# Altium schematic sheet power.SchDoc
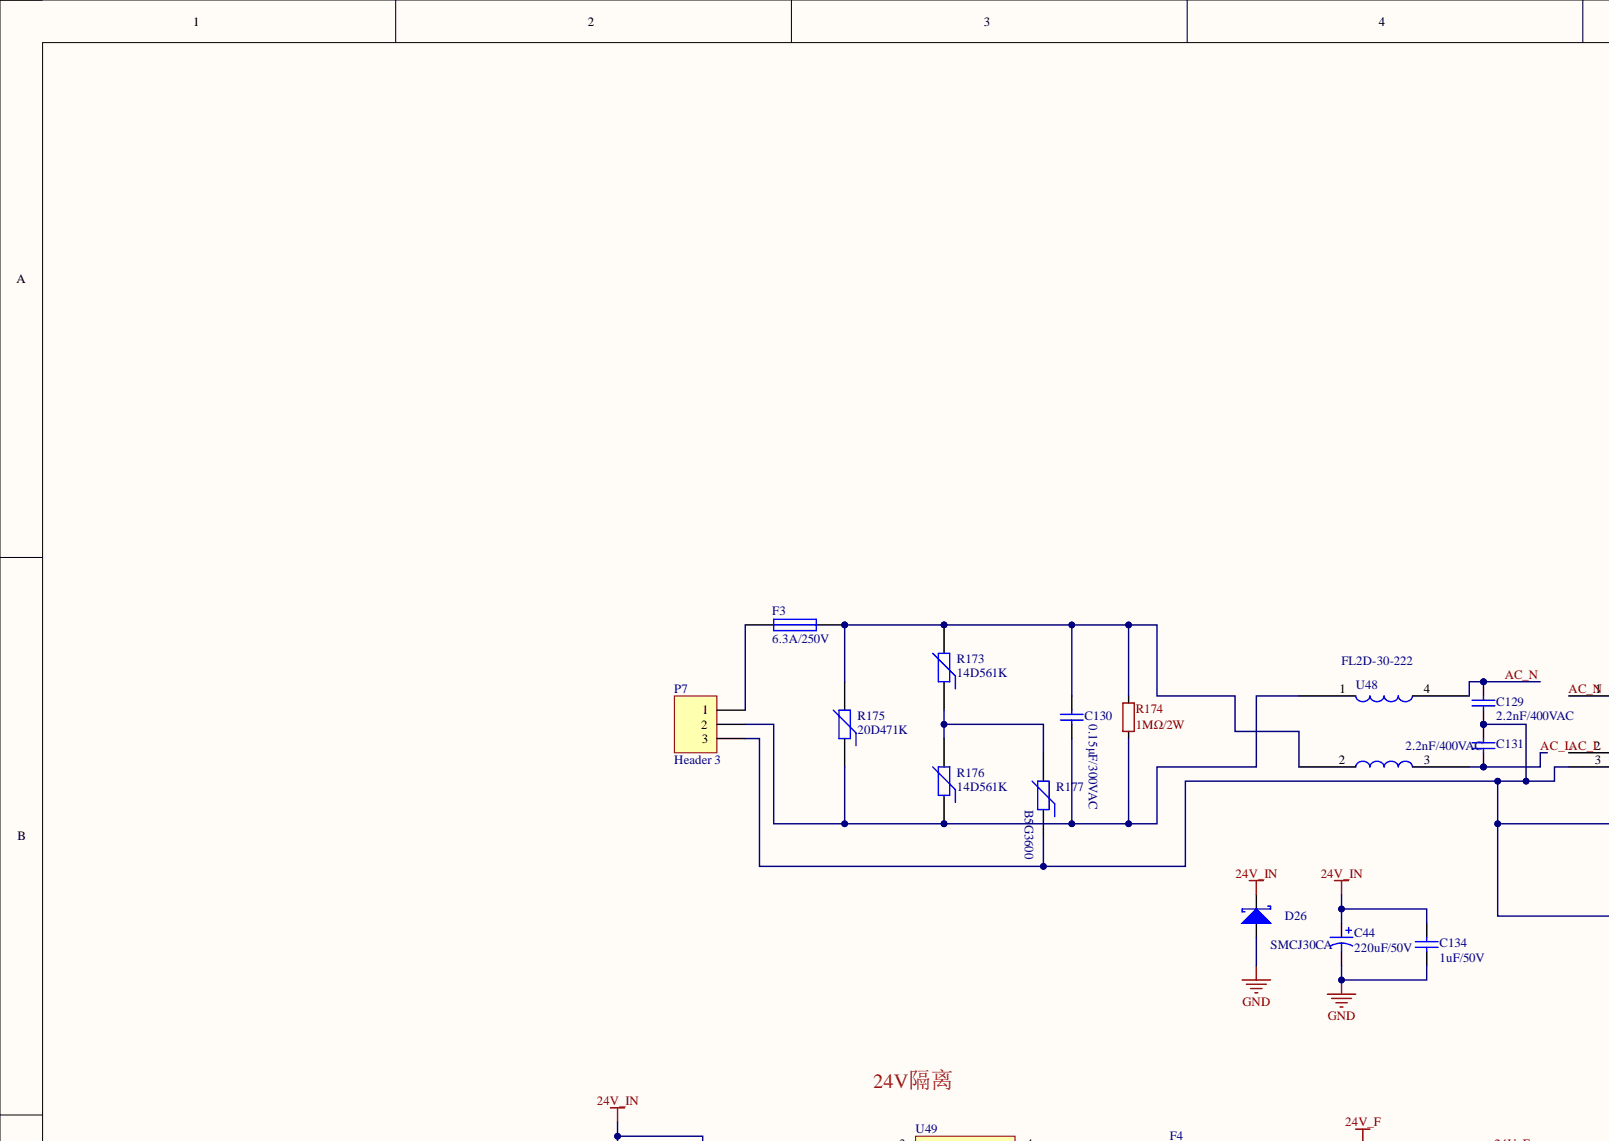
[diagram p0: power.SchDoc, top left]
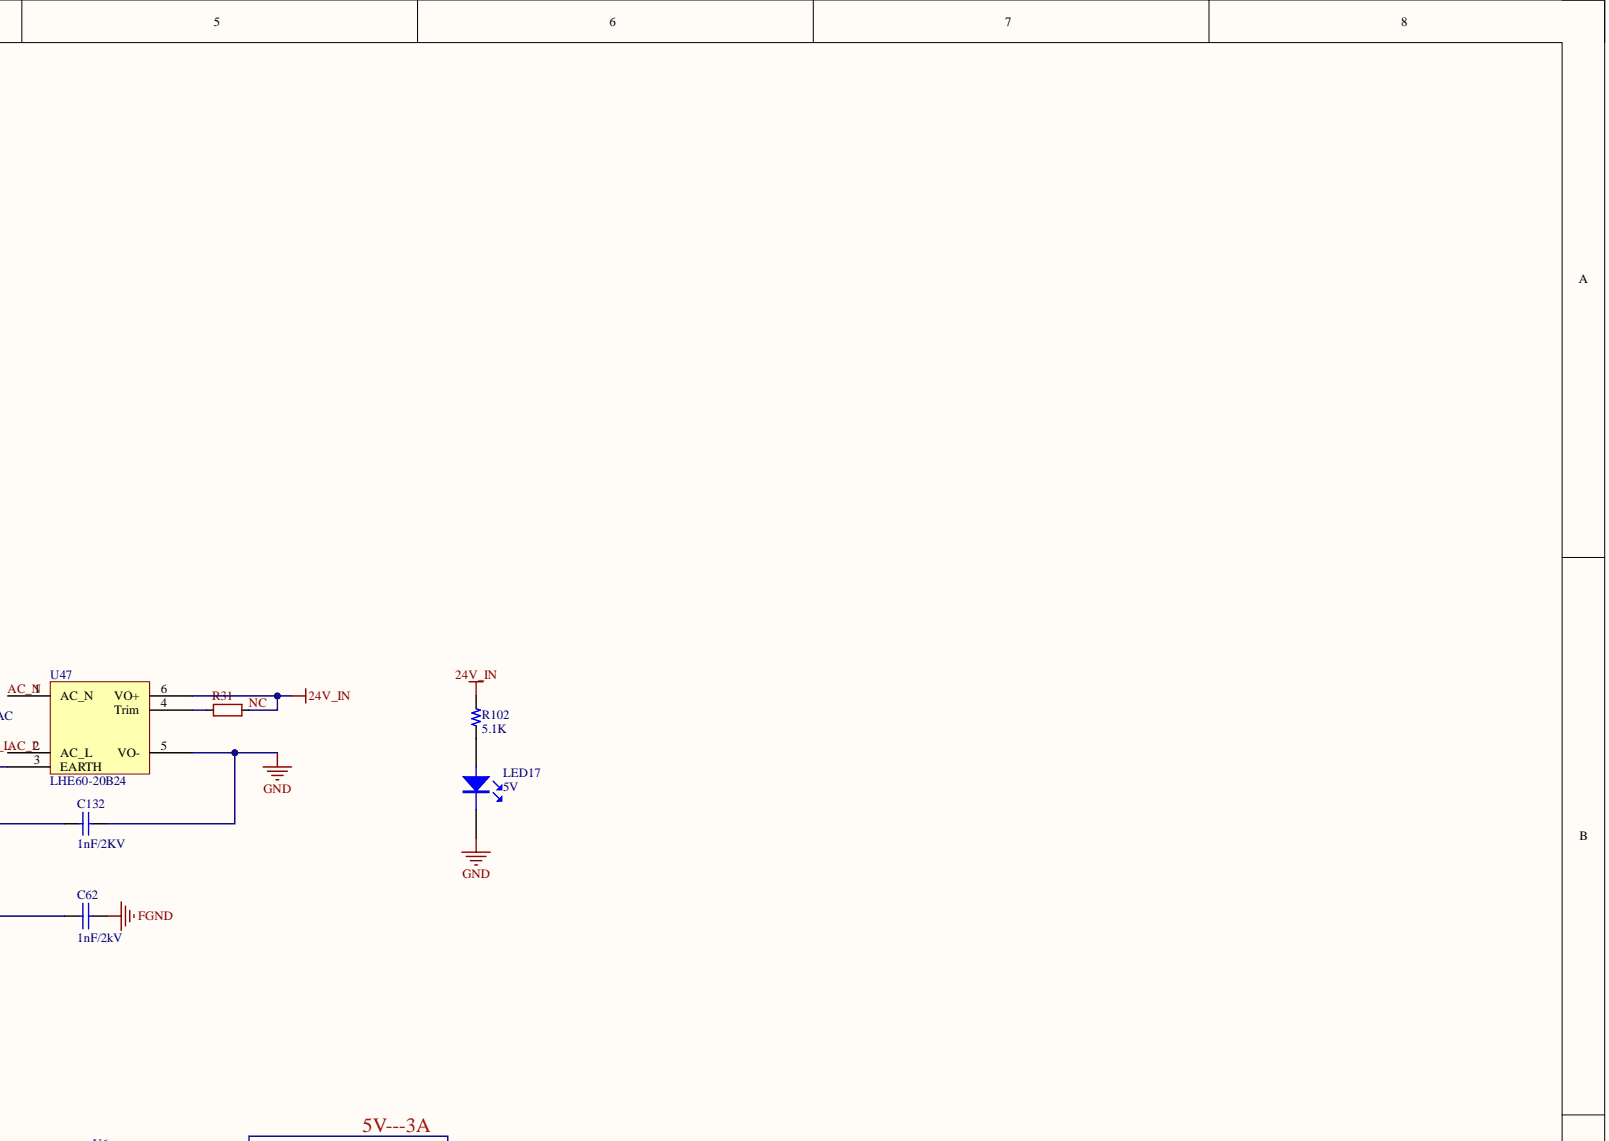
[diagram p1: power.SchDoc, top right]
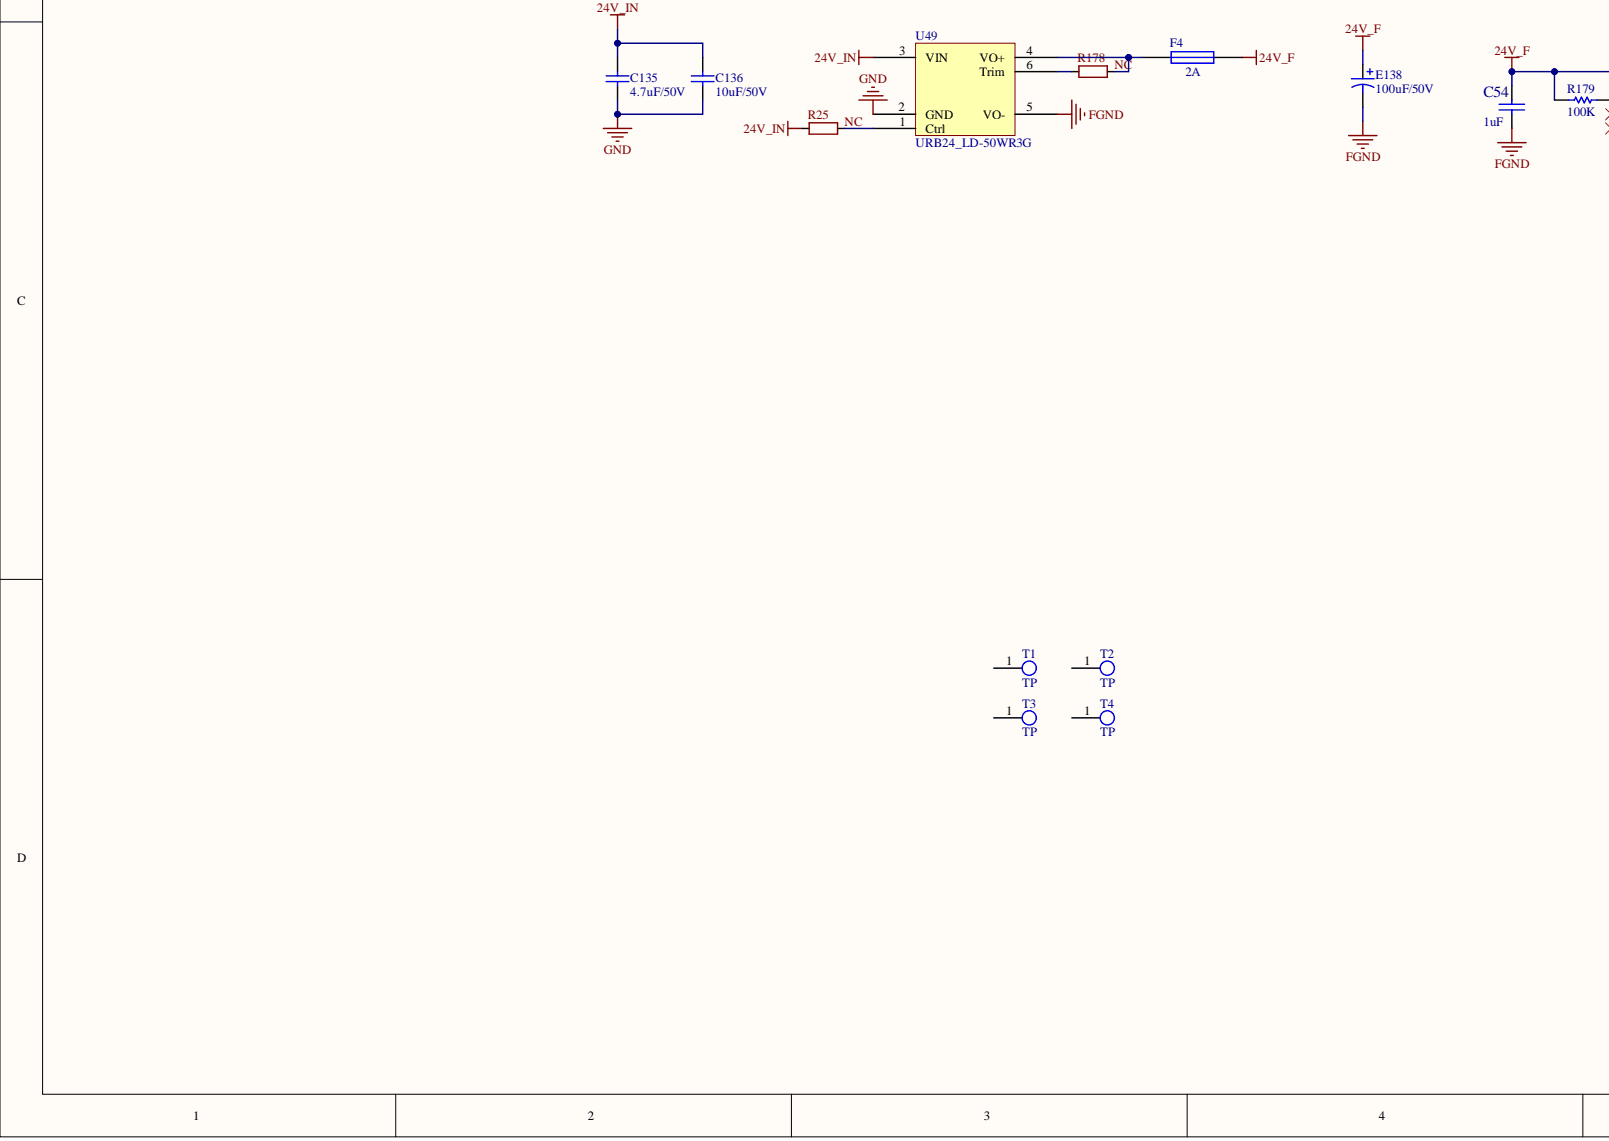
[diagram p2: power.SchDoc, bottom left]
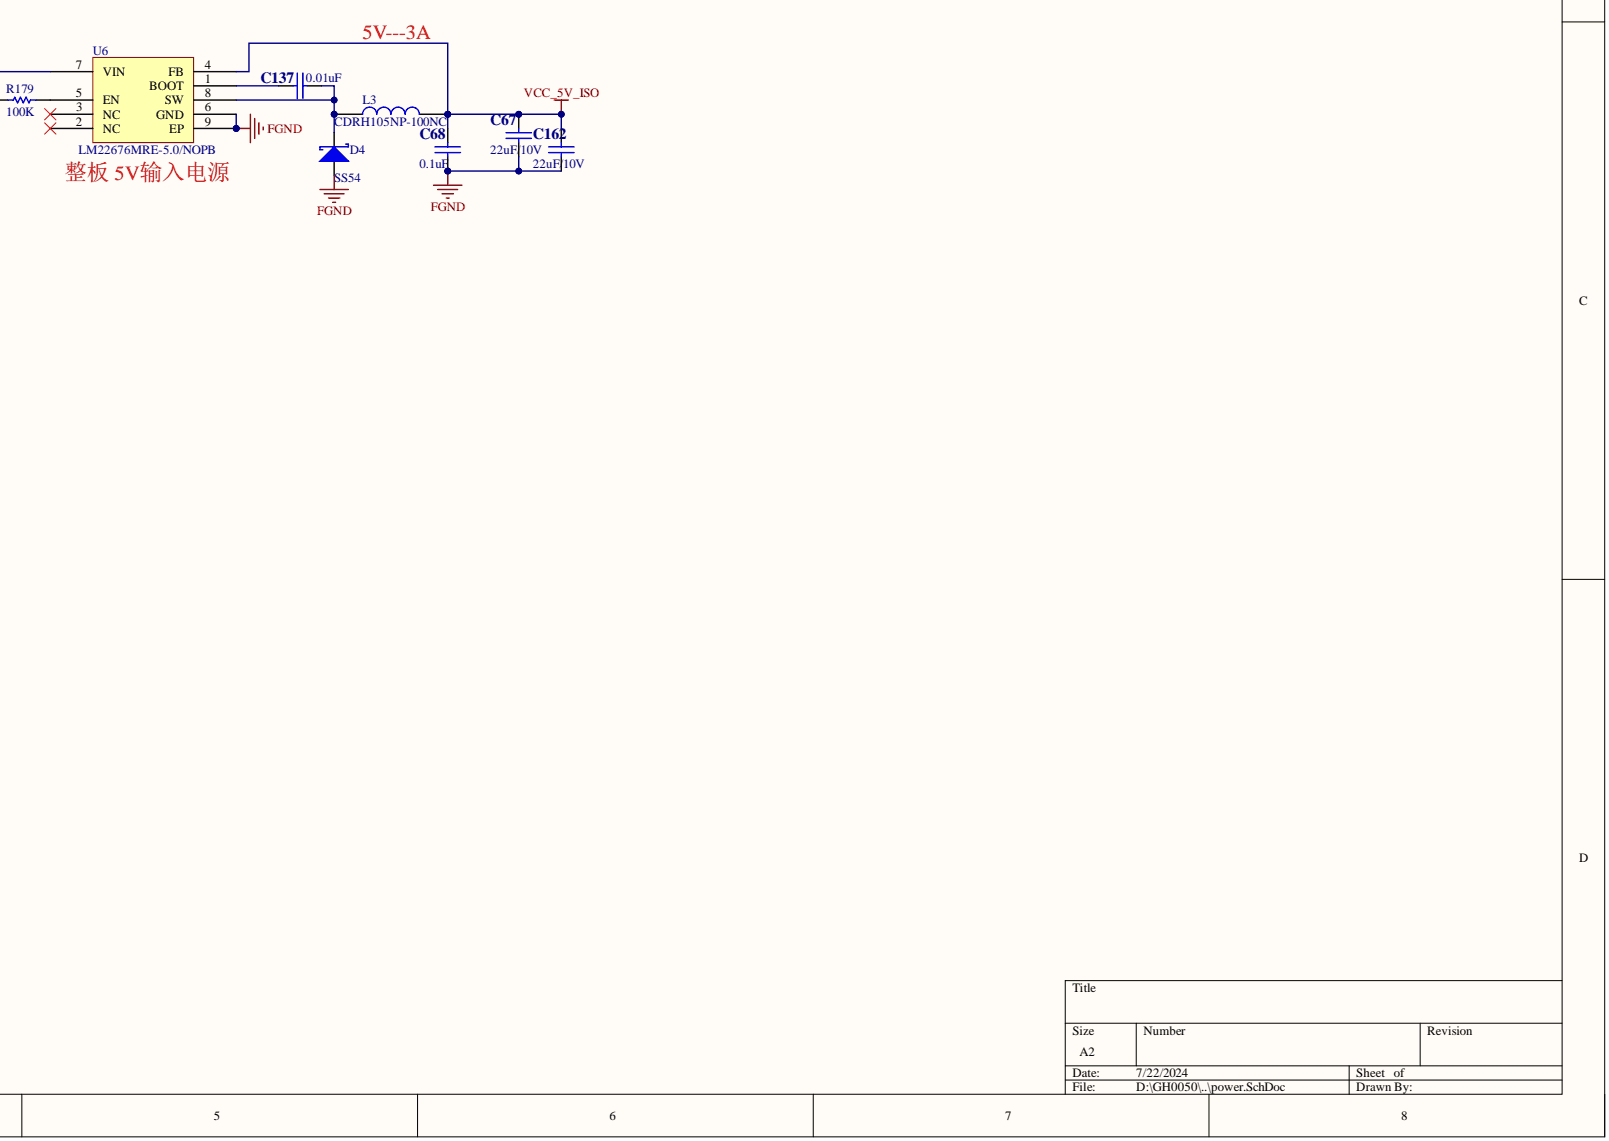
[diagram p3: power.SchDoc, bottom right]

## Parts
C44  220uF/50V  [Φ10×10]
C54  1uF  [0603C]
C62  1nF/2kV  [1206C]
C67  22uF/10V  [1210C]
C68  0.1uF  [0603C]
C129  2.2nF/400VAC  [15x18]
C130  0.15μF/300VAC  [15x18]
C131  2.2nF/400VAC  [15x18]
C132  1nF/2KV  [1206C]
C134  1uF/50V  [1210C]
C135  4.7uF/50V  [1210C]
C136  10uF/50V  [1210C]
C137  0.01uF  [0603C]
C162  22uF/10V  [1210C]
D4  SS54  [SMC]
D26  SMCJ30CA  [SMC]
E138  100uF/50V  [Φ10×10]
F3  6.3A/250V  [可视开关电源保险丝座]
F4  2A  [1812-F]
L3  CDRH105NP-100NC  [CDRH105]
LED17  5V  [0603LED]
P7  Header 3  [KF128V-3P]
R25  NC  [0603r]
R31  NC  [0603r]
R102  5.1K  [0603R]
R173  14D561K  [14D561K]
R174  1MΩ/2W  [5x15.5]
R175  20D471K  [20D471K]
R176  14D561K  [14D561K]
R177  B5G3600  [B5G3600]
R178  NC  [0603r]
R179  100K  [0603R]
T1  TP  [m2.5]
T2  TP  [m2.5]
T3  TP  [m2.5]
T4  TP  [m2.5]
U6  LM22676MRE-5.0/NOPB  [SOP127P600X168_HS-8N]
U47  LHE60-20B24  [LHE60-20B24]
U48  FL2D-30-222  [TY-100P]
U49  URB24_LD-50WR3G  [URB24_LD-50WR3G]

## Nets
24V_F: C54.2 E138.1 F4.2 R179.2 U6.7(VIN)
24V_IN: C44.1 C134.2 C135.2 C136.2 D26.2 R25.1 R31.2 R102.2 U47.6(VO+) U49.3(VIN)
FGND: C54.1 C62.1 C67.2 C68.2 C162.2 D4.1 E138.2 U6.6(GND) U6.9(EP) U49.5(VO-)
GND: C44.2 C132.1 C134.1 C135.1 C136.1 D26.1 LED17.2 U47.5(VO-) U49.2(GND)
VCC_5V_ISO: C67.1 C68.1 C162.1 L3.2 U6.4(FB)
AC_L: C131.1 U47.2(AC_L) U48.3
AC_N: C129.2 U47.1(AC_N) U48.4
NetC62_2: C62.2 C129.1 C131.2 C132.2 P7.3 R177.1 U47.3(EARTH)
NetC130_1: C130.1 P7.2 R174.2 R175.1 R176.1 U48.1
NetC130_2: C130.2 F3.2 R173.2 R174.1 R175.2 U48.2
NetC137_1: C137.1 D4.2 L3.1 U6.8(SW)
NetC137_2: C137.2 U6.1(BOOT)
NetF3_1: F3.1 P7.1
NetF4_1: F4.1 R178.2 U49.4(VO+)
NetLED17_1: LED17.1 R102.1
NetR25_2: R25.2 U49.1(Ctrl)
NetR31_1: R31.1 U47.4(Trim)
NetR173_1: R173.1 R176.2 R177.2
NetR178_1: R178.1 U49.6(Trim)
NetR179_1: R179.1 U6.5(EN)

Not connected: T1 1; T2 1; T3 1; T4 1; U6 2(NC) 3(NC)

## Library data of the parts
C44 = Cap Pol1: Polarized Capacitor (Radial); Component Kind: Standard; LatestRevisionDate: 17-Jul-2002; LatestRevisionNote: Re-released for DXP Platform.; Library Name: Miscellaneous Devices.IntLib; PackageReference: RB7.6-15; Published: 8-Jun-2000; Signal Integrity: Cap Pol; Simulation: CAP; Value: 220uF/50V; Publisher: Altium Limited
C54 C62 C67 C68 C137 C162 = CAP: Capacitor; Component Kind: Standard
C129-C132 C134-C136 = Cap: Capacitor; Value: 22nF; Component Kind: Standard; LatestRevisionDate: 17-Jul-2002; LatestRevisionNote: Re-released for DXP Platform.; Library Name: Miscellaneous Devices.IntLib; PackageDescription: Radial Cap, Thru-Hole; 2 Leads; 0.3 in Pin Spacing; PackageReference: RAD-0.3; Published: 8-Jun-2000; Signal Integrity: Cap; Simulation: CAP; Publisher: Altium Limited
D4 D26 = DIODE S: Schottky Diode; Component Kind: Standard
E138 = Cap Pol1: Polarized Capacitor (Radial); Value: 100pF; Component Kind: Standard; LatestRevisionDate: 17-Jul-2002; LatestRevisionNote: Re-released for DXP Platform.; Library Name: Miscellaneous Devices.IntLib; PackageReference: RB7.6-15; Published: 8-Jun-2000; Signal Integrity: Cap Pol; Simulation: CAP; Publisher: Altium Limited
F3 F4 = Fuse 1: Fuse; Component Kind: Standard; LatestRevisionDate: 17-Jul-2002; LatestRevisionNote: Re-released for DXP Platform.; Library Name: Miscellaneous Devices.IntLib; PackageDescription: Thru-Hole; 2 Leads; PackageReference: PIN-W2/E2.8; Published: 8-Jun-2000; Simulation: FUSE; Publisher: Altium Limited
L3 = INDUCTOR: Component Kind: Standard
LED17 = LED: Component Kind: Standard
P7 = Header 3: Header, 3-Pin; Component Kind: Standard; LatestRevisionDate: 17-Jul-2002; LatestRevisionNote: Re-released for DXP Platform.; Library Name: Miscellaneous Connectors.IntLib; Signal Integrity: Connector; Publisher: Altium Limited
R25 R31 R174 R178 = RES0603: ID: 233; Value: 100K; 制造商: 三星/YAGEO; 参数值: 1.00E+04; 器件等级: ——; 工作温度: –55°C to 155°C; 序号: 109; 物料主编码(PN): 150000040; 物料名称: 贴片电阻; 物料描述: RES,贴片电阻,0603,10k,±1%,1/10W,1.6X0.8X0.45mm,RC1608F103CS/RC0603FR-0710KL; 物料类型: 表贴电阻; 精度: ±1%; 规格型号(完整型号): RC1608F103CS/RC0603FR-0710KL; Component Kind: Standard; Library Name: RC_M_D_00.IntLib
R102 = R: Component Kind: Standard; Description: R; Library Name: JRJ_1.SCHLIB
R173 R175-R177 = Res Varistor: Varistor (Voltage-Sensitive Resistor); Code_IPC: RESC2012; Component Kind: Standard; LatestRevisionDate: 29-May-2009; LatestRevisionNote: IPC-7351 Footprint Added.; Library Name: Miscellaneous Devices.IntLib; PackageDescription: Chip Resistor 0805 Case Size; PackageReference: 6-0805; PackageVersion: Mar-2003; Published: 24-Mar-1999; Publisher: Altium Limited
R179 = Res1: Resistor; Component Kind: Standard; LatestRevisionDate: 17-Jul-2002; LatestRevisionNote: Re-released for DXP Platform.; Library Name: Miscellaneous Devices.IntLib; PackageReference: AXIAL-0.3; Published: 8-Jun-2000; Signal Integrity: Res; Simulation: RESISTOR; Publisher: Altium Limited
U6 = LM22676MR-5.0: Component Kind: Standard; Library Name: Schlib1.SchLib
U48 = TY-100P: PCB mounting filters; Component Kind: Standard; Library Name: power.SCHLIB
T1-T4 = TP: Component Kind: Standard; Library Name: Schlib_20110825.schlib
U47 = LHE60-20B24: Component Kind: Standard; Library Name: Schlib1.SchLib
U49 = URB24_LD-50WR3G: Component Kind: Standard; Library Name: Schlib1.SchLib
